# Altium schematic sheet Controller.SchDoc
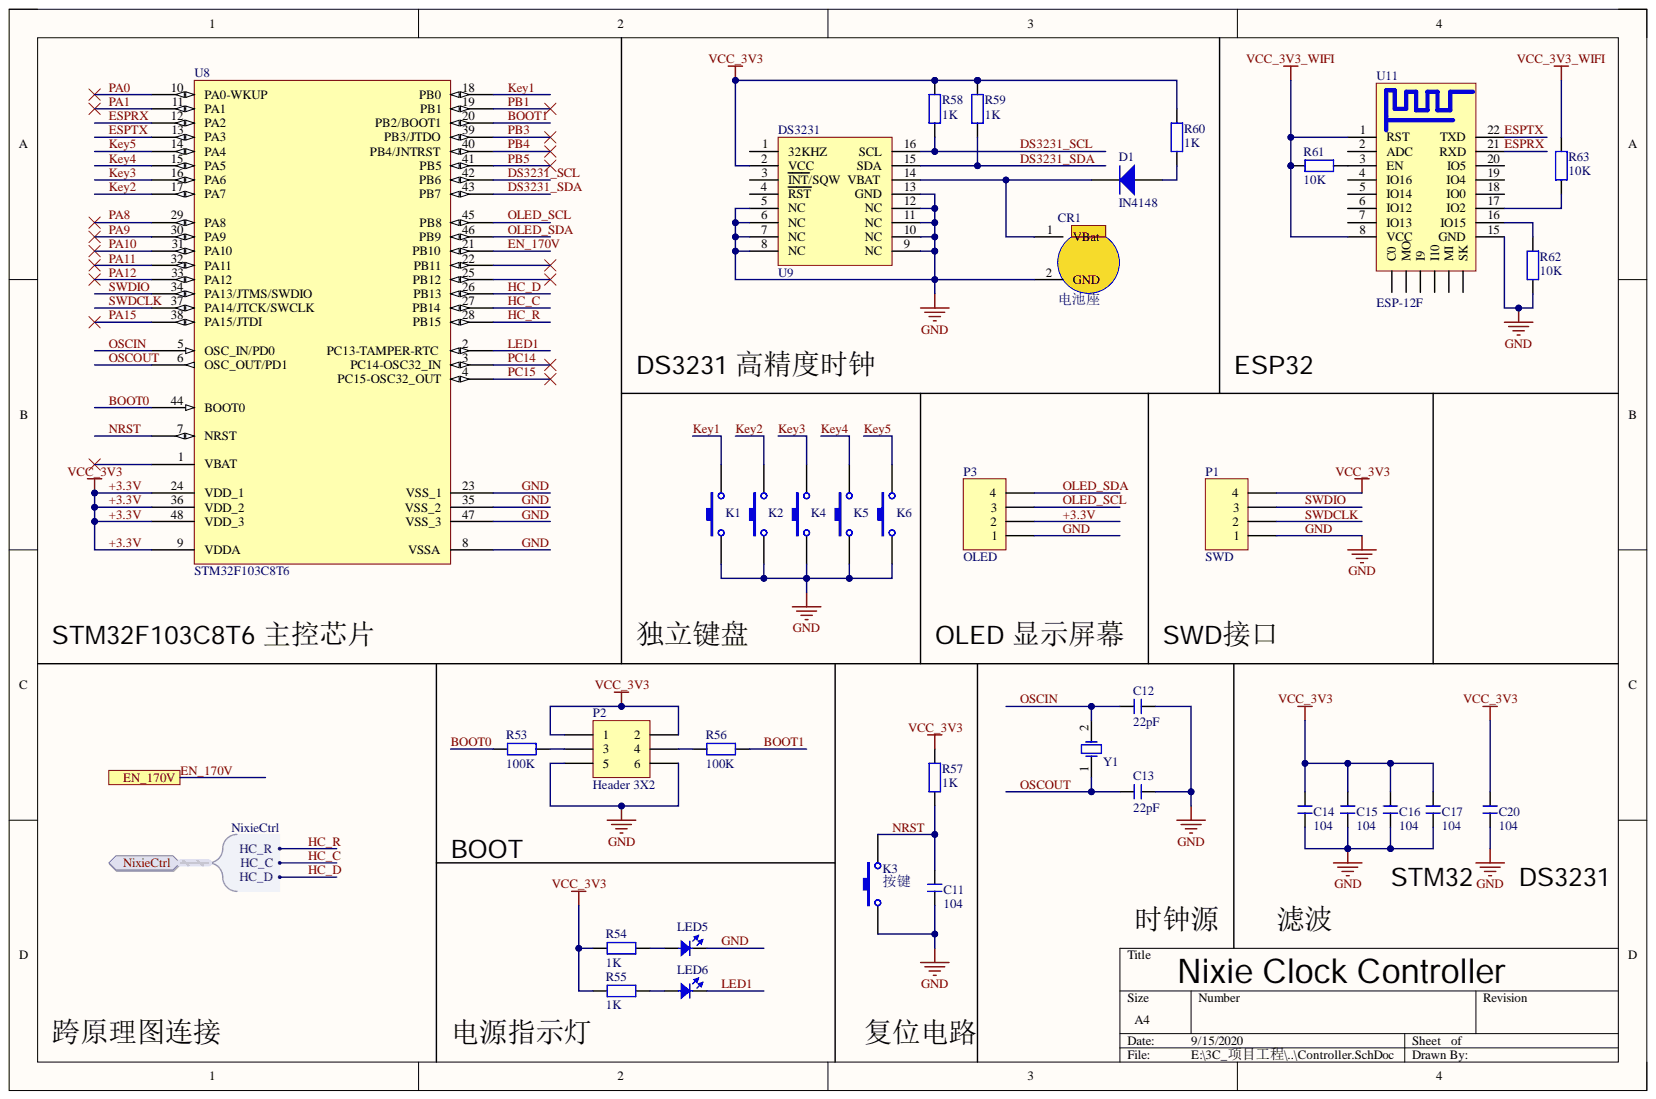
[diagram p0: Controller.SchDoc]

## Parts
C11  104  [C 0805]
C12  22pF  [C 0805]
C13  22pF  [C 0805]
C14  104  [C 0805]
C15  104  [C 0805]
C16  104  [C 0805]
C17  104  [C 0805]
C20  104  [C 0805]
CR1  电池座  [CR2032 电池座]
D1  IN4148  [0805D]
K1  按键  [按键]  (value not drawn)
K2  按键  [按键]  (value not drawn)
K3  按键  [按键]
K4  按键  [按键]  (value not drawn)
K5  按键  [按键]  (value not drawn)
K6  按键  [按键]  (value not drawn)
LED5  LED  [0805D]
LED6  LED  [0805D]
P1  SWD  [HDR1X4]
P2  Header 3X2  [HDR2X3]
P3  OLED  [HDR1X4]
R53  100K  [R 0805]
R54  1K  [R 0805]
R55  1K  [R 0805]
R56  100K  [R 0805]
R57  1K  [R 0805]
R58  1K  [R 0805]
R59  1K  [R 0805]
R60  1K  [R 0805]
R61  10K  [R 0805]
R62  10K  [R 0805]
R63  10K  [R 0805]
U8  STM32F103C8T6  [LQFP48 7X7_M]
U9  DS3231  [SOL-16]
U11  ESP-12F  [ESP-12F]
Y1  XTAL  [XTAL1]  (value not drawn)

## Ports
EN_170V, NixieCtrl, NixieCtrl.HC_C, NixieCtrl.HC_D, NixieCtrl.HC_R

## Nets
VCC_3V3_WIFI: R61.1 R63.2 U11.1(RST) U11.8(VCC)
+3.3V: C14.1 C15.1 C16.1 C17.1 C20.2 P1.4 P2.1 P2.2 P3.2 R54.1 R55.1 R57.2 R58.2 R59.2 R60.1 U8.9(VDDA) U8.24(VDD_1) U8.36(VDD_2) U8.48(VDD_3) U9.2(VCC)
BOOT0: R53.1 U8.44(BOOT0)
BOOT1: R56.2 U8.20(PB2/BOOT1)
DS3231_SCL: R58.1 U8.42(PB6) U9.16(SCL)
DS3231_SDA: R59.1 U8.43(PB7) U9.15(SDA)
EN_170V: U8.21(PB10) ; port EN_170V
ESPRX: U8.12(PA2) U11.21(RXD)
ESPTX: U8.13(PA3) U11.22(TXD)
GND: C11.1 C12.2 C13.2 C14.2 C15.2 C16.2 C17.2 C20.1 CR1.2 K1.1 K2.1 K3.1 K4.1 K5.1 K6.1 LED5.2 P1.1 P2.5 P2.6 P3.1 R62.1 U8.8(VSSA) U8.23(VSS_1) U8.35(VSS_2) U8.47(VSS_3) U9.5(NC) U9.6(NC) U9.7(NC) U9.8(NC) U9.9(NC) U9.10(NC) U9.11(NC) U9.12(NC) U9.13(GND) U11.15
HC_C: U8.27(PB14) ; port NixieCtrl
HC_D: U8.26(PB13) ; port NixieCtrl
HC_R: U8.28(PB15) ; port NixieCtrl
Key1: K1.2 U8.18(PB0)
Key2: K2.2 U8.17(PA7)
Key3: K4.2 U8.16(PA6)
Key4: K5.2 U8.15(PA5)
Key5: K6.2 U8.14(PA4)
LED1: LED6.2 U8.2(PC13-TAMPER-RTC)
NRST: C11.2 K3.2 R57.1 U8.7(NRST)
OLED_SCL: P3.3 U8.45(PB8)
OLED_SDA: P3.4 U8.46(PB9)
OSCIN: C12.1 U8.5(OSC_IN/PD0) Y1.2
OSCOUT: C13.1 U8.6(OSC_OUT/PD1) Y1.1
PA0: U8.10(PA0-WKUP)
PA1: U8.11(PA1)
PA8: U8.29(PA8)
PA9: U8.30(PA9)
PA10: U8.31(PA10)
PA11: U8.32(PA11)
PA12: U8.33(PA12)
PA15: U8.38(PA15/JTDI)
PB1: U8.19(PB1)
PB3: U8.39(PB3/JTDO)
PB4: U8.40(PB4/JNTRST)
PB5: U8.41(PB5)
PC14: U8.3(PC14-OSC32_IN)
PC15: U8.4(PC15-OSC32_OUT)
SWDCLK: P1.2 U8.37(PA14/JTCK/SWCLK)
SWDIO: P1.3 U8.34(PA13/JTMS/SWDIO)
NetCR1_1: CR1.1 D1.2 U9.14(VBAT)
NetD1_1: D1.1 R60.2
NetLED5_1: LED5.1 R54.2
NetLED6_1: LED6.1 R55.2
NetP2_3: P2.3 R53.2
NetP2_4: P2.4 R56.1
NetR61_2: R61.2 U11.3(EN)
NetR62_2: R62.2 U11.16(IO15)
NetR63_1: R63.1 U11.17(IO2)

Not connected: U8 1(VBAT) 22(PB11) 25(PB12); U9 1(32KHZ) 3(INT/SQW) 4(RST); U11 2(ADC) 4(IO16) 5(IO14) 6(IO12) 7(IO13) 9(C0) 10(MO) 11(I9) 12(I10) 13(MI) 18(IO0) 19(IO4) 20(IO5)

## Library data of the parts
C11-C17 C20 = C: 无极性贴片电容; 版权归属: 源创客; BOM名称: 无极性贴片电容; Component Kind: Standard; Library Name: 电阻-电容-电感.SchLib; 学习资源: www.ooocke.com
CR1 = CR2032 电池座: Component Kind: Standard; Library Name: 常用元件库.SCHLIB
D1 = 二级管: Component Kind: Standard; Library Name: 常用元件库.SCHLIB
P1 P3 = Header 4: Header, 4-Pin; Component Kind: Standard; LatestRevisionDate: 17-Jul-2002; LatestRevisionNote: Re-released for DXP Platform.; Library Name: Miscellaneous Connectors.IntLib; Signal Integrity: Connector; Publisher: Altium Limited
P2 = Header 3X2: Header, 3-Pin, Dual row; Component Kind: Standard; LatestRevisionDate: 17-Jul-2002; LatestRevisionNote: Re-released for DXP Platform.; Library Name: Miscellaneous Connectors.IntLib; Signal Integrity: Connector; Publisher: Altium Limited
R53-R63 = R: 贴片电阻; 版权归属: 源创客; BOM名称: 贴片电阻; Component Kind: Standard; Library Name: 电阻-电容-电感.SchLib; 学习资源: www.ooocke.com
U8 = STM32F103C8T6: Flaah:64/RAM:20/IO:36; BOM名称: 32位STM单片机; 版权归属: 源创客; Component Kind: Standard; Library Name: STM32系列单片机.SchLib; 学习资源: www.ooocke.com
K1-K6 = 按键: Component Kind: Standard; Library Name: 常用元件库.SCHLIB
LED5 LED6 = LED: Component Kind: Standard; LatestRevisionDate: 08-Jul-2005; LatestRevisionNote: Mech Layer 1 removed.; Library Name: 常用元件库.SCHLIB; PackageDescription: LED; 2 Leads; PackageReference: LED-0; Published: 8-Jun-2000; Publisher: Altium Limited
U9 = DS3231: Component Kind: Standard; Library Name: 常用元件库.SCHLIB
U11 = ESP-12F: Component Kind: Standard; Library Name: 史福祥库.SchLib
Y1 = XTAL: Component Kind: Standard; LatestRevisionDate: 17-Jul-2002; LatestRevisionNote: Re-released for DXP Platform.; Library Name: 常用元件库.SCHLIB; PackageDescription: Crystal, Thru-Hole; 2 Leads; Body 3.1 x 8.2 mm (Dia.xH); PackageReference: R38; Published: 8-Jun-2000; Publisher: Altium Limited
